# Altium schematic sheet Sheet1.SchDoc(Sheet1)
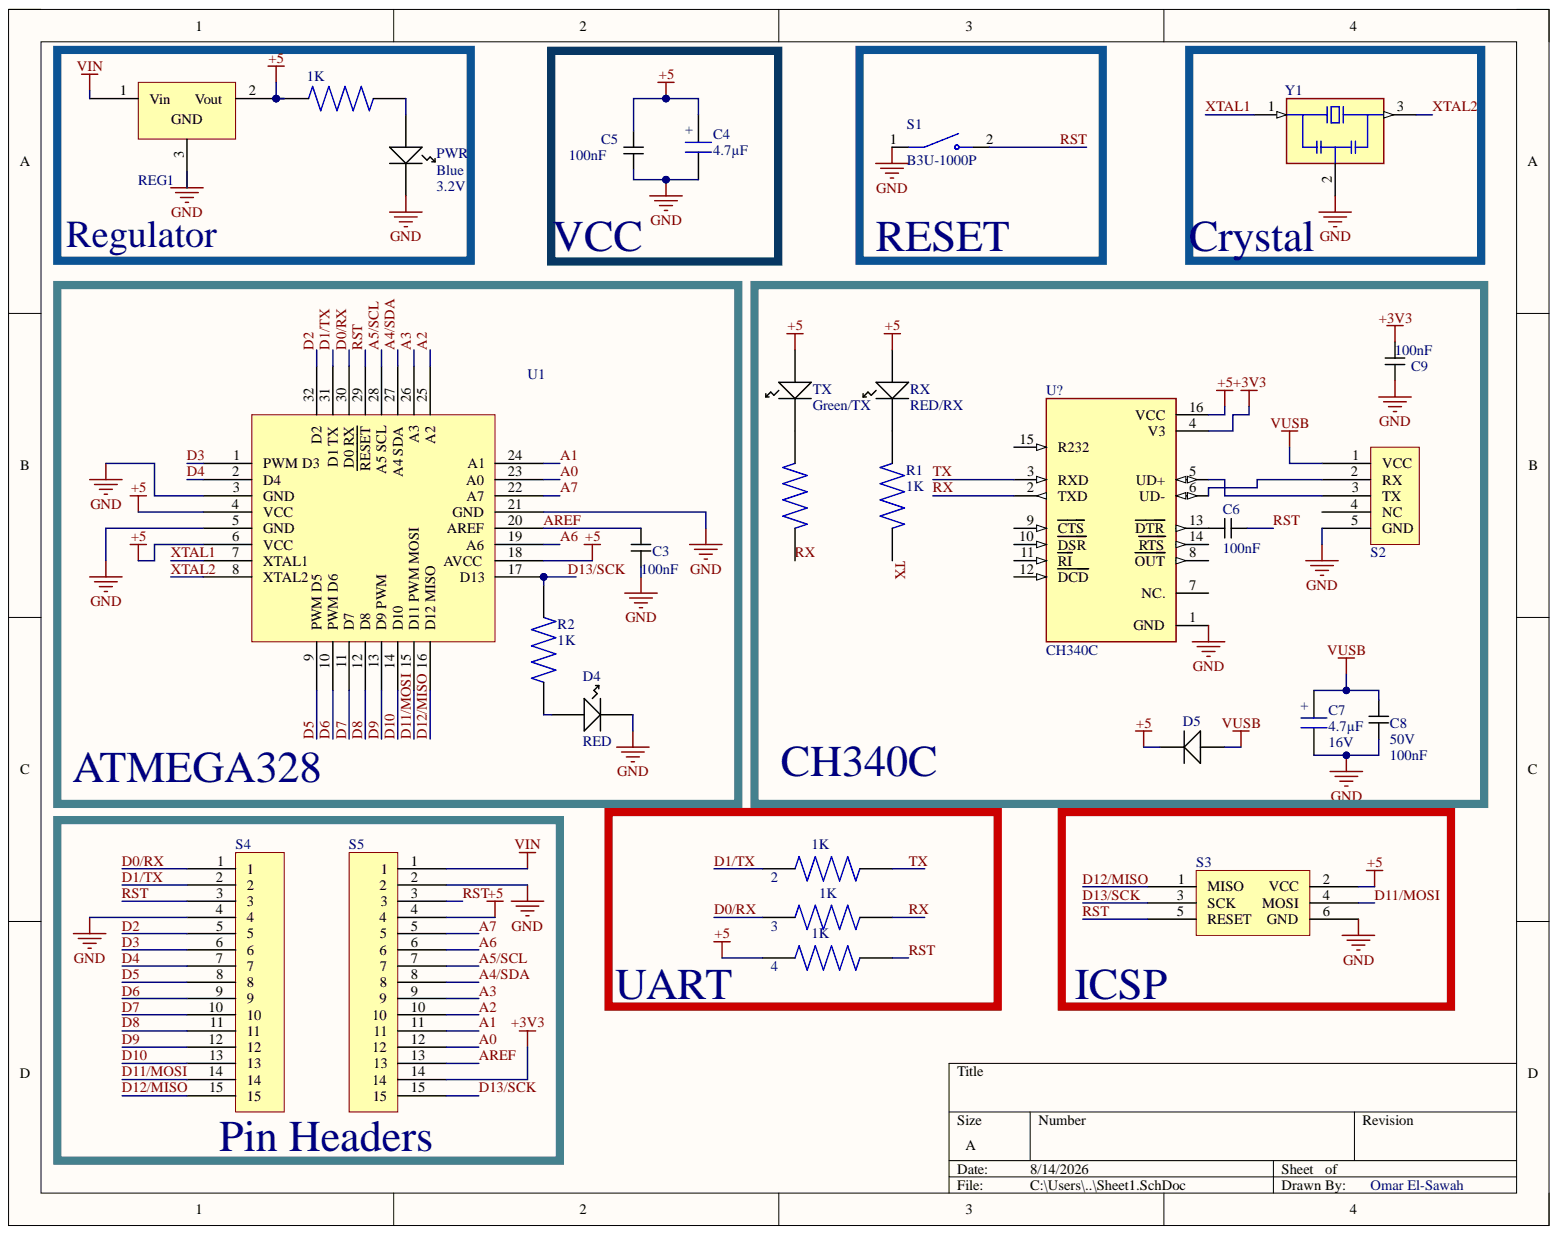
[diagram p0: Sheet1.SchDoc]

## Parts
1K  ERA3AEB8870V  [RES_ERA3AEB8870V]  (value not drawn)
2  1K  [RES_ERA3AEB8870V]
3  1K  [RES_ERA3AEB8870V]
4  1K  [RES_ERA3AEB8870V]
C3  Capacitance: 100nF  [CAPCP2012X09N]  (hidden comment: 100nF Ceramic Multilayer Capacitor_1)
C4  value 4.7µF  [CASE A - 3216X18]  (hidden comment: Capacitor SMT Tantalum)
C5  Capacitance: 100nF  [CAPCP2012X09N]  (hidden comment: 100nF Ceramic Multilayer Capacitor_1)
C6  Capacitance: 100nF  [CAPCP2012X09N]  (hidden comment: 100nF Ceramic Multilayer Capacitor_1)
C7  value 4.7µF  Voltage: 16V  [CASE A - 3216X18]  (hidden comment: Capacitor SMT Tantalum)
C8  Capacitance: 100nF  Voltage: 50V  [CAPCP2012X09N]  (hidden comment: 100nF Ceramic Multilayer Capacitor_1)
C9  Capacitance: 100nF  [CAPCP2012X09N]  (hidden comment: 100nF Ceramic Multilayer Capacitor_1)
D4  Color: RED  [LED1913X12NRED]  (hidden comment: Kingbright Red LED, 100 mcd 120 ° 0805)
D5  Panasonic DB2J20900L Schottky Diode, 0.5A, 20V, 2.4ns, 2-Pin SMini2 F5 B  [DIOM1712X07N]  (value not drawn)
PWR  Color: Blue  Forward Voltage: 3.2V  [LED1913X12NBLUE]  (hidden comment: Kingbright Blue LED, 60 mcd 120 ° 0805)
R1  1K  [RES_ERA3AEB8870V]
R2  1K  [RES_ERA3AEB8870V]
REG1  LM1117MP-5.0/NOPB, Low Dropout Voltage Regulator, 1.5A, 5 V ±1%, 4-Pin SOT-223  [SOT230P700X180-4N]  (value not drawn)
RX  Color: RED/RX  [LED1913X12NRED]  (hidden comment: Kingbright Red LED, 100 mcd 120 ° 0805)
S1  B3U-1000P  [SW_B3U-1000P]
S2  NewMolex Right Angle SMT Female Type B Micro USB Connector, 30 V ac, 1.8A  [MicroUSB]  (value not drawn)
S3  Programming Headers Dual Row  [ISP HEADER]  (value not drawn)
S4  connector;str header;single row;.1 cont centers;.095 tail;gold or gtx;36 contact  [Header]  (value not drawn)
S5  connector;str header;single row;.1 cont centers;.095 tail;gold or gtx;36 contact  [Header]  (value not drawn)
TX  Color: Green/TX  [LED1913X12NGREEN]  (hidden comment: Kingbright Green LED, 70 mcd 120 ° 0805)
U1  ATMEGA328  [TQFP80P900X900X120-32N]
U?  CH340C  [SOIC127P600X180-16N]
Y1  CSTCE16M3V53-R0  [OSC_CSTCE16M3V53-R0]  (value not drawn)
  ERA3AEB8870V  [RES_ERA3AEB8870V]  (value not drawn)

## Nets
+3V3: C9.2 S5.14 U?.4(V3)
+5: 1K.2 4.1 C4.1 C5.2 D5.1 REG1.2 RX.1 S3.2(VCC) S5.4 TX.1 U1.4(VCC) U1.6(VCC) U1.18(AVCC) U?.16(VCC)
GND: C3.2 C4.2 C5.1 C7.2 C8.2 C9.1 D4.2 PWR.2 REG1.3 S1.1 S2.5(GND) S3.6(GND) S4.4 S5.2 U1.3(GND) U1.5(GND) U1.21(GND) U?.1(GND) Y1.2
Net_1: TX.2 .1
VIN: REG1.1 S5.1
VUSB: C7.1 C8.1 D5.2 S2.1(VCC)
A0: S5.12 U1.23(A0)
A1: S5.11 U1.24(A1)
A2: S5.10 U1.25(A2)
A3: S5.9 U1.26(A3)
A4/SDA: S5.8 U1.27(A4_SDA)
A5/SCL: S5.7 U1.28(A5_SCL)
A6: S5.6 U1.19(A6)
A7: S5.5 U1.22(A7)
AREF: C3.1 S5.13 U1.20(AREF)
D0/RX: 3.1 S4.1 U1.30(D0_RX)
D1/TX: 2.1 S4.2 U1.31(D1_TX)
D2: S4.5 U1.32(D2)
D3: S4.6 U1.1(PWM_D3)
D4: S4.7 U1.2(D4)
D5: S4.8 U1.9(PWM_D5)
D6: S4.9 U1.10(PWM_D6)
D7: S4.10 U1.11(D7)
D8: S4.11 U1.12(D8)
D9: S4.12 U1.13(D9_PWM)
D10: S4.13 U1.14(D10)
D11/MOSI: S3.4(MOSI) S4.14 U1.15(D11_PWM_MOSI)
D12/MISO: S3.1(MISO) S4.15 U1.16(D12_MISO)
D13/SCK: R2.2 S3.3(SCK) S5.15 U1.17(D13)
RST: 4.2 C6.1 S1.2 S3.5(RESET) S4.3 S5.3 U1.29(RESET)
RX: 3.2 U?.2(TXD) .2
TX: 2.2 R1.1 U?.3(RXD)
XTAL1: U1.7(XTAL1) Y1.1
XTAL2: U1.8(XTAL2) Y1.3
Net1K_1: 1K.1 PWR.1
NetC6_2: C6.2 U?.13(DTR)
NetD4_1: D4.1 R2.1
NetR1_2: R1.2 RX.2
NetS2_2: S2.2(RX) U?.6(UD-)
NetS2_3: S2.3(TX) U?.5(UD+)

Not connected: S2 4(NC); U? 7(NC.) 8(OUT) 9(CTS) 10(DSR) 11(RI) 12(DCD) 14(RTS) 15(R232)

## Library data of the parts
2-4 R1 R2 = ERA3AEB8870V: Availability: Unavailable; Component Kind: Standard; Library Name: ERA3AEB8870V.IntLib; MP: ERA3AEB8870V; Package: None; Price: None
C3 C5 C6 C9 = 100nF Ceramic Multilayer Capacitor_1: AVX 0805 Standard 100nF Ceramic Multilayer Capacitor, 50 V dc X7R Dielectric ±10% SMD; Voltage: 50V; Component Kind: Standard; Datasheet URL: http://za.rs-online.com/web/p/ceramic-multilayer-capacitors/4646688/; Date Created: 18 February 2015; Library Name: RS Components - Capacitors.IntLib; Manufacturer: AVX; Package: 0805; RS Stock No.: 464-6688; Creator: Ritesh Kanjee
C4 C7 = 4.7µF Tant RS 496-3545: Capacitor,tantalum,SMT,16V,4.7uF,10%,A-case; Part Originator: H Theron; Component Kind: Standard; ComponentLink1Description: Supplier Website; ComponentLink1URL: http://za.rs-online.com/web/search/searchBrowseAction.html?method=getProduct&R=4963545; ComponentLink2Description: RS Data sheet; ComponentLink2URL: http://docs-europe.origin.electrocomponents.com/webdocs/04ed/0900766b804ed4cc.pdf; LatestRevisionDate: 28-April-2010; Library Name: RS Components - Capacitors.IntLib; Package: 3216-18; RS Stock Nr.: 496-3545; Tolerance: 10%; Publisher: DPSS
  C4: Voltage: 16V
C8 = 100nF Ceramic Multilayer Capacitor_1: AVX 0805 Standard 100nF Ceramic Multilayer Capacitor, 50 V dc X7R Dielectric ±10% SMD; Component Kind: Standard; Datasheet URL: http://za.rs-online.com/web/p/ceramic-multilayer-capacitors/4646688/; Date Created: 18 February 2015; Library Name: RS Components - Capacitors.IntLib; Manufacturer: AVX; Package: 0805; RS Stock No.: 464-6688; Creator: Ritesh Kanjee
D4 RX = Kingbright Red LED, 100 mcd 120 ° 0805: Manufacturer Part No.: KP-2012SRC-PRV; Forward Voltage: 1.85V; Component Kind: Standard; Datasheet URL: http://za.rs-online.com/web/p/visible-leds/4663813/; Date Created: 18 February 2015; Description: Kingbright Red LED, 100 mcd 120 ° 0805; Library Name: KingBright LEDs.IntLib; Manufacturer: KingBright; RS Stock No.: 466-3813; Creator: Ritesh Kanjee
D5 = Schottky Diode, 0.5A, 20V, 2.4ns, 2-Pin SMini2 F5 B: Component Kind: Standard; Datasheet: http://docs-europe.electrocomponents.com/webdocs/1398/0900766b813986b3.pdf; Date Created: 1 March 2015; Description: Panasonic DB2J20900L Schottky Diode, 0.5A, 20V, 2.4ns, 2-Pin SMini2 F5 B; Library Name: Panasonic Diodes.IntLib; Manufacturer: Panasonic; Manufacturer Part No.: DB2J20900L; Maximum Continuous Forward Current: 0.5A; Peak Reverse Repetitive Voltage: 20V; RS Stock No.: 713-2703; Created By: R Kanjee
PWR = Kingbright Blue LED, 60 mcd 120 ° 0805: Manufacturer Part No.: KP-2012PBC-A; Component Kind: Standard; Datasheet URL: http://za.rs-online.com/web/p/visible-leds/4663784/; Date Created: 18 February 2015; Description: Kingbright Blue LED, 60 mcd 120 ° 0805; Library Name: KingBright LEDs.IntLib; Manufacturer: KingBright; RS Stock No.: 466-3784; Creator: Ritesh Kanjee
REG1 = LM1117MP-5.0: Manufacturer: Texas Instruments; Maximum Input Voltage: 15V; Output Voltage: 5V; Supply Current: 1.5Amps; Component Kind: Standard; Datasheet URL: http://za.rs-online.com/web/p/low-dropout-voltage-regulators/5339583/; Date Created: 26 February 2015; Description: LM1117MP-5.0/NOPB, Low Dropout Voltage Regulator, 1.5A, 5 V ±1%, 4-Pin SOT-223; Library Name: TI Low Dropout Voltage Regulator.IntLib; RS Stock No.: 533-9583; Created By: R Kanjee
S1 = B3U-1000P: Switch Tactile N.O. SPST Round Button Gull Wing 0.05A 12VDC 1.5N SMD Embossed T/R; Availability: Unavailable; Component Kind: Standard; Library Name: B3U-1000P.IntLib; MP: B3U-1000P; Package: None; Price: None
S2 = Micro USB Connector, 30 V ac, 1.8A: Manufacturer: Molex; Manufacturer Part No.: 105017-0001; Max Voltage: 30V; Component Kind: Standard; Datasheet URL: http://za.rs-online.com/web/p/micro-usb-connectors/8486764/; Date Created: 28 February 2015; Description: NewMolex Right Angle SMT Female Type B Micro USB Connector, 30 V ac, 1.8A; Library Name: Molex Connectors.IntLib; Max Current: 1.8A; RS Stock No: 848-6764; Creator: R Kanjee
S3 = Programming ISP, Programming Headers Dual Row: Manufacturer: FCI; Manufacturer Part No.: 68000-236HLF; Current Rating: 3Amps Continuous; Component Kind: Standard; Date Created: 1 March 2015; Description: Programming Headers Dual Row; Library Name: PCB Headers.IntLib; RS Stock No.: 2509565626; Created By: R Kanjee
S4 S5 = Single row; PCB headers: Manufacturer: FCI; Manufacturer Part No.: 68000-236HLF; Current Rating: 3Amps Continuous; Component Kind: Standard; Date Created: 1 March 2015; Description: connector;str header;single row;.1 cont centers;.095 tail;gold or gtx;36 contact; Library Name: PCB Headers.IntLib; RS Stock No.: 2509565626; Created By: R Kanjee
TX = Kingbright Green LED, 70 mcd 120 ° 0805: Manufacturer Part No.: KP-2012MGC; Forward Voltage: 2.1 V; Component Kind: Standard; Datasheet URL: http://za.rs-online.com/web/p/visible-leds/4663778/; Date Created: 18 February 2015; Description: Kingbright Green LED, 70 mcd 120 ° 0805; Library Name: KingBright LEDs.IntLib; Manufacturer: KingBright; RS Stock No.: 466-3778; Creator: Ritesh Kanjee
U1 = ATMEGA328P-AU: ATMEGA328P-AU, 8 bit AVR Microcontroller 20MHz 1 kB, 32 kB Flash, 2 kB RAM, I2C 32-Pin TQFP; Company: Lumina Inova; Component Kind: Standard; Datasheet URL: http://docs-europe.electrocomponents.com/webdocs/0db4/0900766b80db4deb.pdf; Date Created: 14 February 2015; Library Name: Atmel Microcontrollers.IntLib; RS Stock No.: 696-3092; Created By: Ritesh Kanjee
U? = CH340C: USB to serial chip CH340; MF: WCH; Availability: Not in stock; Check_prices: https://www.snapeda.com/parts/CH340C/WCH/view-part/?ref=eda; Component Kind: Standard; Library Name: CH340C.IntLib; MP: CH340C; Package: None; Price: None; SnapEDA_Link: https://www.snapeda.com/parts/CH340C/WCH/view-part/?ref=snap
Y1 = CSTCE16M3V53-R0: Resonators RECOMMENDED ALT 81-CSTNE16M3V530000R; MF: Murata; Check_prices: https://www.snapeda.com/parts/CSTCE16M3V53-R0/Murata/view-part/?ref=eda; Component Kind: Standard; Library Name: CSTCE16M3V53-R0.IntLib; MP: CSTCE16M3V53-R0; Package: SMD-3 Murata; SnapEDA_Link: https://www.snapeda.com/parts/CSTCE16M3V53-R0/Murata/view-part/?ref=snap
1K  = ERA3AEB8870V: Availability: Unavailable; Component Kind: Standard; Library Name: ERA3AEB8870V.IntLib; MP: ERA3AEB8870V; Package: None; Price: None
